# Altium schematic sheet [17]-ETH_CONNECTOR.SchDoc([17]-ETH_CONNECTOR)
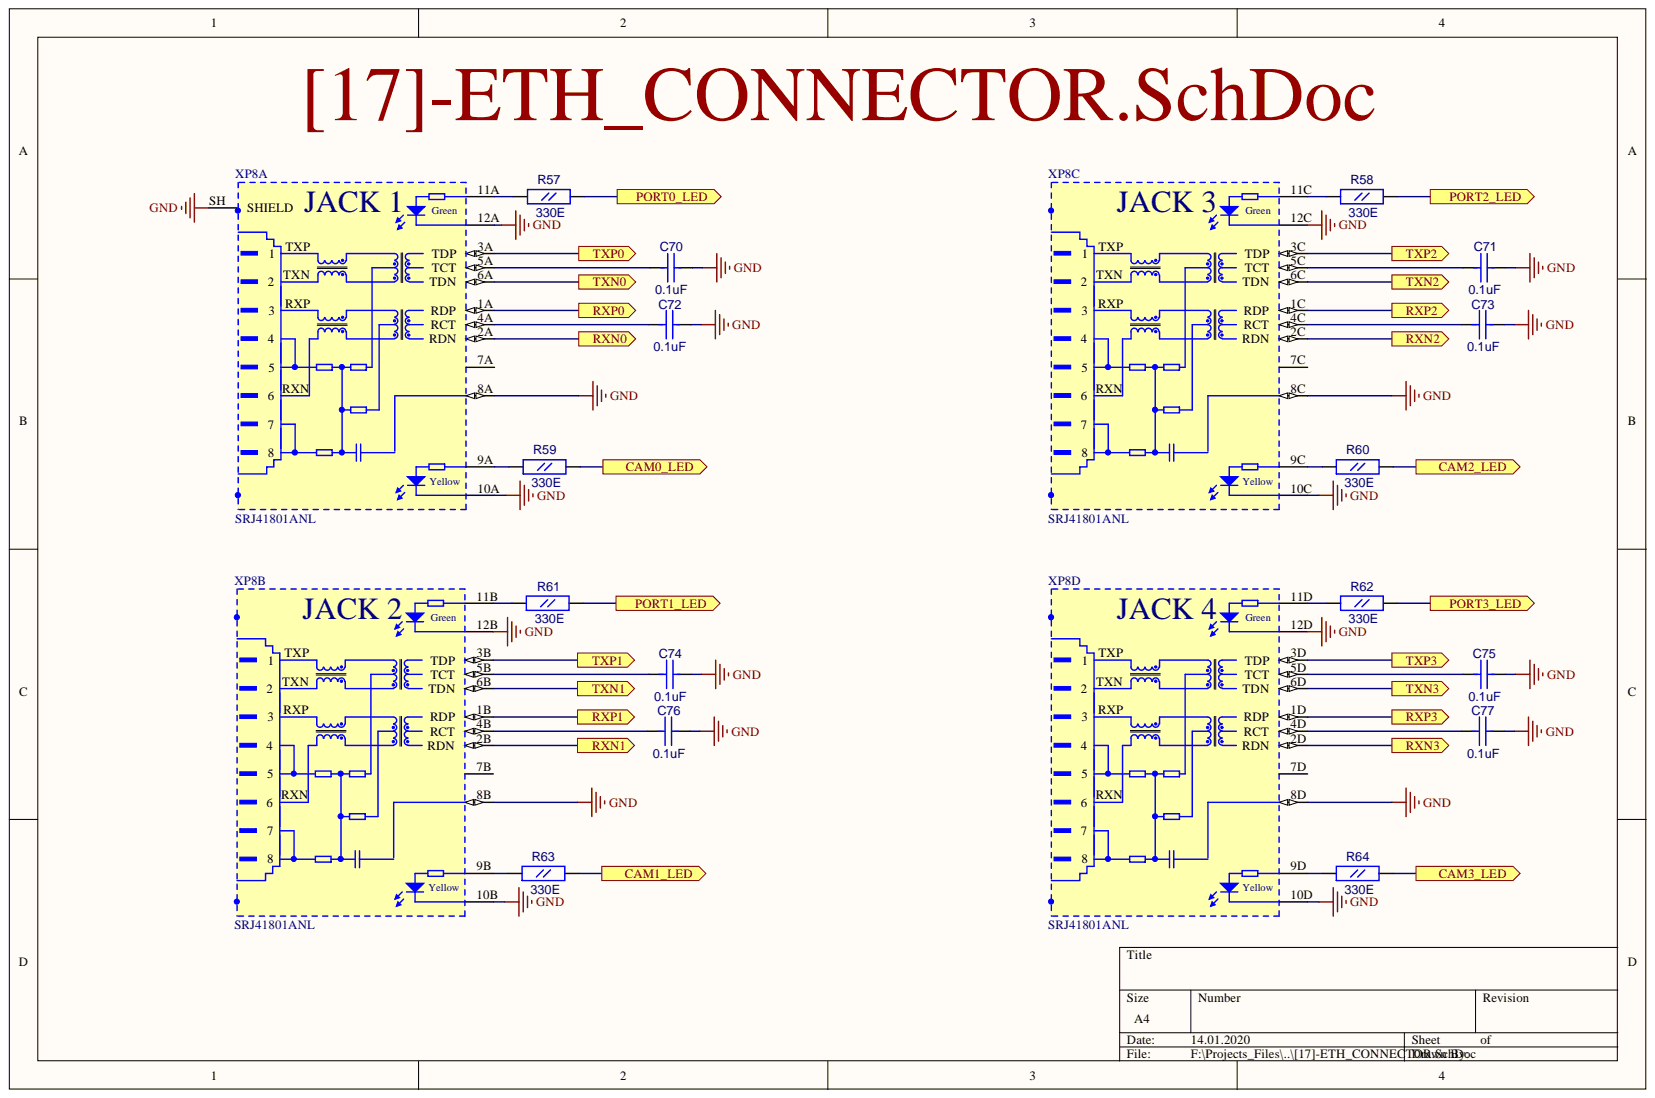
[diagram p0: [17]-ETH_CONNECTOR.SchDoc]

## Parts
C70  0.1uF  [CC0603]
C71  0.1uF  [CC0603]
C72  0.1uF  [CC0603]
C73  0.1uF  [CC0603]
C74  0.1uF  [CC0603]
C75  0.1uF  [CC0603]
C76  0.1uF  [CC0603]
C77  0.1uF  [CC0603]
R57  330E  [RC0603]
R58  330E  [RC0603]
R59  330E  [RC0603]
R60  330E  [RC0603]
R61  330E  [RC0603]
R62  330E  [RC0603]
R63  330E  [RC0603]
R64  330E  [RC0603]
XP8  SRJ41801ANL  [ETHERNET_RJ45_4PORTS]  (parts A,B,C,D)

## Ports
CAM0_LED, CAM1_LED, CAM2_LED, CAM3_LED, PORT0_LED, PORT1_LED, PORT2_LED, PORT3_LED, RXN0, RXN1, RXN2, RXN3, RXP0, RXP1, RXP2, RXP3, TXN0, TXN1, TXN2, TXN3, TXP0, TXP1, TXP2, TXP3

## Nets
GND: C70.2 C71.2 C72.2 C73.2 C74.2 C75.2 C76.2 C77.2 XP8.8A XP8.8B XP8.8C XP8.8D XP8.10A XP8.10B XP8.10C XP8.10D XP8.12A XP8.12B XP8.12C XP8.12D XP8.SH(SHIELD)
CAM0_LED: R59.2 ; port CAM0_LED
CAM1_LED: R63.2 ; port CAM1_LED
CAM2_LED: R60.2 ; port CAM2_LED
CAM3_LED: R64.2 ; port CAM3_LED
PORT0_LED: R57.2 ; port PORT0_LED
PORT1_LED: R61.2 ; port PORT1_LED
PORT2_LED: R58.2 ; port PORT2_LED
PORT3_LED: R62.2 ; port PORT3_LED
RXN0: XP8.2A(RDN) ; port RXN0
RXN1: XP8.2B(RDN) ; port RXN1
RXN2: XP8.2C(RDN) ; port RXN2
RXN3: XP8.2D(RDN) ; port RXN3
RXP0: XP8.1A(RDP) ; port RXP0
RXP1: XP8.1B(RDP) ; port RXP1
RXP2: XP8.1C(RDP) ; port RXP2
RXP3: XP8.1D(RDP) ; port RXP3
TXN0: XP8.6A(TDN) ; port TXN0
TXN1: XP8.6B(TDN) ; port TXN1
TXN2: XP8.6C(TDN) ; port TXN2
TXN3: XP8.6D(TDN) ; port TXN3
TXP0: XP8.3A(TDP) ; port TXP0
TXP1: XP8.3B(TDP) ; port TXP1
TXP2: XP8.3C(TDP) ; port TXP2
TXP3: XP8.3D(TDP) ; port TXP3
NetC70_1: C70.1 XP8.5A(TCT)
NetC71_1: C71.1 XP8.5C(TCT)
NetC72_1: C72.1 XP8.4A(RCT)
NetC73_1: C73.1 XP8.4C(RCT)
NetC74_1: C74.1 XP8.5B(TCT)
NetC75_1: C75.1 XP8.5D(TCT)
NetC76_1: C76.1 XP8.4B(RCT)
NetC77_1: C77.1 XP8.4D(RCT)
NetR57_1: R57.1 XP8.11A
NetR58_1: R58.1 XP8.11C
NetR59_1: R59.1 XP8.9A
NetR60_1: R60.1 XP8.9C
NetR61_1: R61.1 XP8.11B
NetR62_1: R62.1 XP8.11D
NetR63_1: R63.1 XP8.9B
NetR64_1: R64.1 XP8.9D

Not connected: XP8 7A 7B 7C 7D

## Library data of the parts
C70-C77 = CC0603: Value: 0.1uF; Description: CC0603; Component Kind: Standard; Library Name: fizik_lib.SchLib
R57-R64 = RC0603: Value: 330E; Description: RC0603; Component Kind: Standard; Library Name: fizik_lib.SchLib
XP8 = SRJ41801ANL: 4 Ports RJ45 Ethernet connector; Value: SRJ41801ANL; Component Kind: Standard; Library Name: fizik_lib.SchLib
